# Altium schematic sheet bridge.SchDoc(bridge)
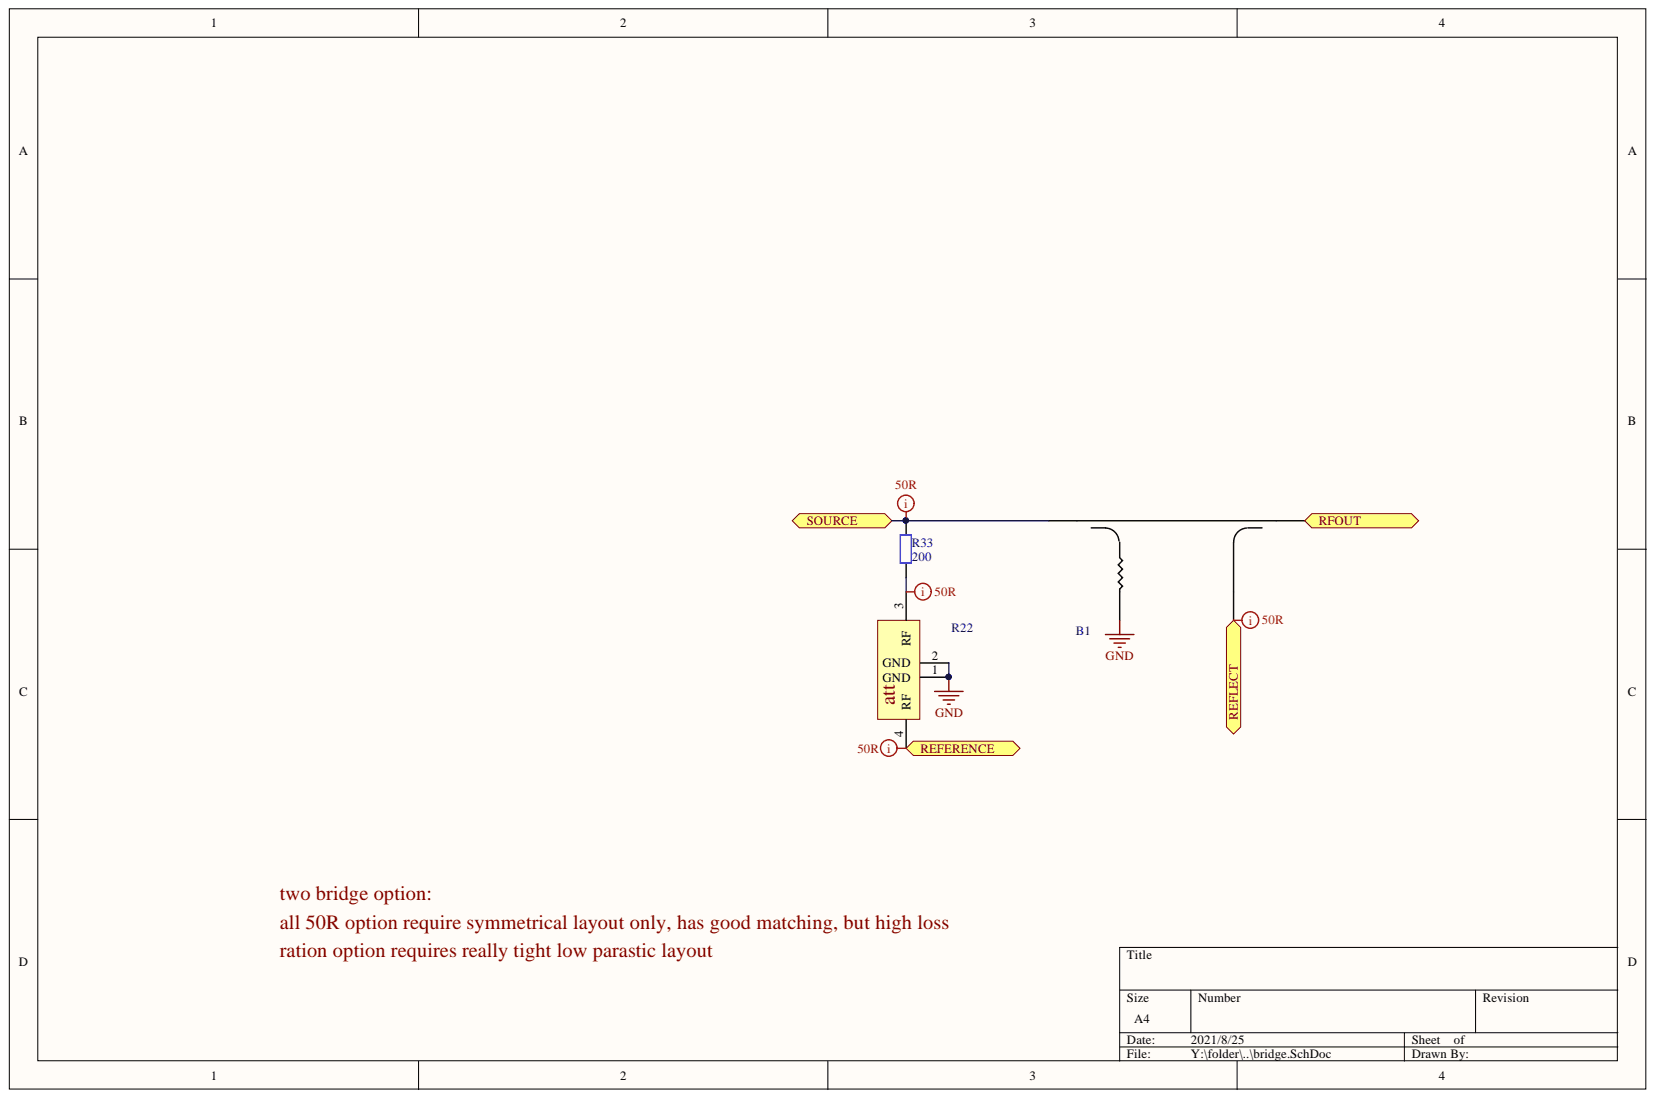
[diagram p0: bridge.SchDoc]

## Parts
B1  BRIDGE  [BRIDGE-4]  (value not drawn)
R22  EXB24-RF-ATT  [EXB24AT-RF-ATT]  (value not drawn)
R33  200  [0201]

## Ports
REFERENCE, REFLECT, RFOUT, SOURCE

## Nets
GND: B1.GND R22.1(GND) R22.2(GND)
REFERENCE: R22.4(RF) ; port REFERENCE
REFLECT: B1.COUPLE ; port REFLECT
RFOUT: B1.OUT ; port RFOUT
SOURCE: B1.SOURCE R33.2 ; port SOURCE
NetR22_3: R22.3(RF) R33.1

## Library data of the parts
R33 = Res2: Resistor; Simulation: RESISTOR; Signal Integrity: Res; Published: 8-Jun-2000; PackageReference: AXIAL-0.4; PackageDescription: Axial Device, Thru-Hole; 2 Leads; 0.4 in Pin Spacing; Library Name: Miscellaneous Devices.IntLib; LatestRevisionNote: Re-released for DXP Platform.; LatestRevisionDate: 17-Jul-2002; Component Kind: Standard; Publisher: Altium Limited
B1 = BRIDGE: Library Name: F4SDR.SchLib; Component Kind: Standard
R22 = EXB24-RF-ATT: Library Name: F4SDR.SchLib; Component Kind: Standard
